# Altium schematic sheet lv_comm_i2c.SchDoc(lv_comm_i2c)
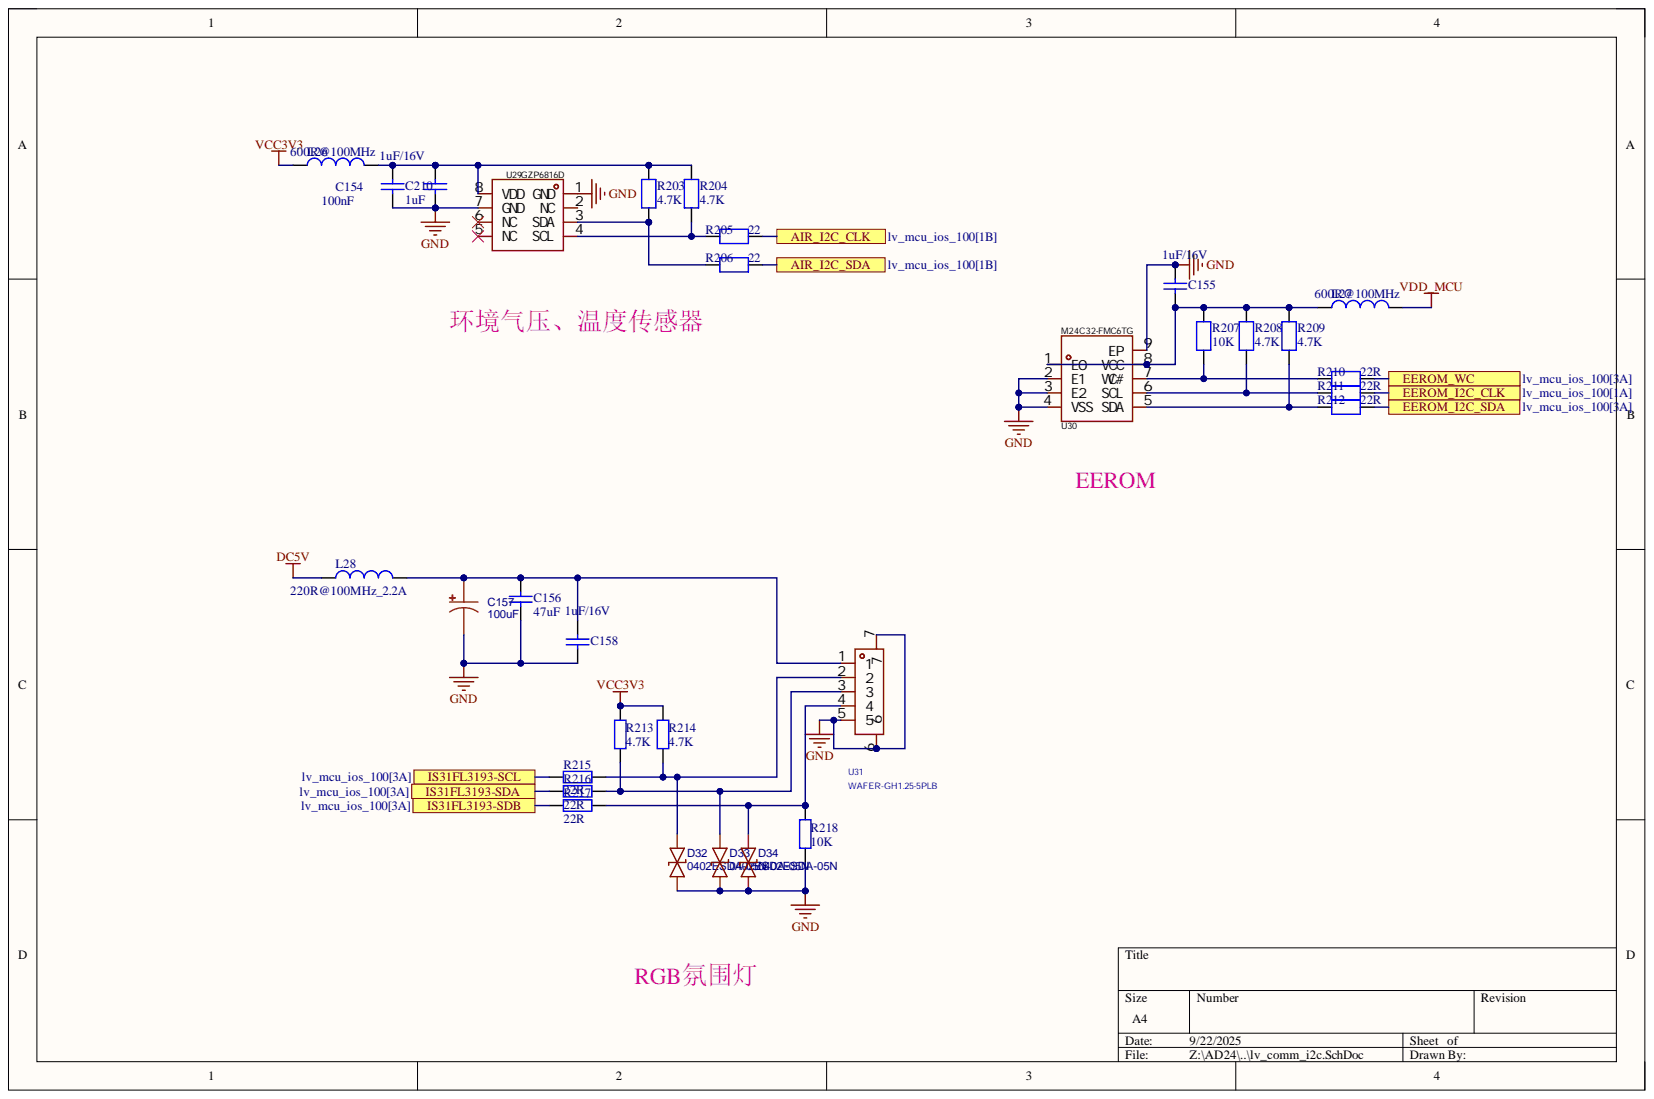
[diagram p0: lv_comm_i2c.SchDoc]

## Parts
C154  100nF  [C0402]
C155  1uF/16V  [C0603]
C156  47uF  [C1210]
C157  100uF  [CAP-SMD_BD6.3-L6.6-W6.6-LS7.6-FD]
C158  1uF/16V  [C0603]
C210  1uF/16V  value 1uF  [C0603]
D32  0402ESDA-05N  [D0402-BI]
D33  0402ESDA-05N  [D0402-BI]
D34  0402ESDA-05N  [D0402-BI]
L26  600R@100MHz  [L0603]
L27  600R@100MHz  [L0603]
L28  220R@100MHz_2.2A  [L0603]
R203  4.7K  [R0402]
R204  4.7K  [R0402]
R205  22  [R0402]
R206  22  [R0402]
R207  10K  [R0402]
R208  4.7K  value 10K  [R0402]
R209  4.7K  [R0402]
R210  22R  [R0402]
R211  22R  [R0402]
R212  22R  [R0402]
R213  4.7K  [R0402]
R214  4.7K  [R0402]
R215  22R  [R0402]
R216  22R  [R0402]
R217  22R  [R0402]
R218  10K  [R0402]
U29  GZP6816D  [SENSOR-SMD_XGZP6816D]
U30  M24C32-FMC6TG  [UFDFPN-8_L2.0-W3.0-P0.50-BL-EP]
U31  WAFER-GH1.25-5PLB  [CONN-SMD_5P-P1.25_XUNPU_WAFER-GH1.25-5PLB]

## Ports
AIR_I2C_CLK, AIR_I2C_SDA, EEROM_I2C_CLK, EEROM_I2C_SDA, EEROM_WC, IS31FL3193-SCL, IS31FL3193-SDA, IS31FL3193-SDB

## Nets
DC5V: L28.2
GND: C154.1 C155.1 C156.1 C157.2 C158.2 C210.2 D32.1 D33.1 D34.1 R218.1 U29.1(GND) U29.7(GND) U30.2(E1) U30.3(E2) U30.4(VSS) U30.9(EP) U31.5 U31.6 U31.7
VCC3V3: L26.1 R213.1 R214.1
VDD_MCU: L27.2
AIR_I2C_CLK: R205.2 ; port AIR_I2C_CLK
AIR_I2C_SDA: R206.2 ; port AIR_I2C_SDA
EEROM_I2C_CLK: R211.1 ; port EEROM_I2C_CLK
EEROM_I2C_SDA: R212.1 ; port EEROM_I2C_SDA
EEROM_WC: R210.1 ; port EEROM_WC
IS31FL3193-SCL: R215.2 ; port IS31FL3193-SCL
IS31FL3193-SDA: R216.2 ; port IS31FL3193-SDA
IS31FL3193-SDB: R217.2 ; port IS31FL3193-SDB
NetC154_2: C154.2 C210.1 L26.2 R203.1 R204.1 U29.8(VDD)
NetC155_2: C155.2 L27.1 R207.2 R208.2 R209.2 U30.1(E0) U30.8(VCC)
NetC156_2: C156.2 C157.1 C158.1 L28.1 U31.1
NetD32_2: D32.2 R214.2 R215.1 U31.2
NetD33_2: D33.2 R213.2 R216.1 U31.3
NetD34_2: D34.2 R217.1 R218.2 U31.4
NetR203_2: R203.2 R206.1 U29.3(SDA)
NetR204_2: R204.2 R205.1 U29.4(SCL)
NetR207_1: R207.1 R210.2 U30.7(WC#)
NetR208_1: R208.1 R211.2 U30.6(SCL)
NetR209_1: R209.1 R212.2 U30.5(SDA)

Not connected: U29 2(NC) 5(NC) 6(NC)

## Library data of the parts
C154 = Capacitor: 50V, 5%, X7R; Manufacturer: muRata; Manufacturer_Part_Number: GRM155R71H104KE14D; Component Kind: Standard; ComponentLink1Description: Datasheet; ComponentLink1URL: http://tunmcdsvn.tun.st.com/Component_Datasheet_Library/Trunk/Capacitors/Yageo_Capacitor_NP0_16V-to-50V; Library Name: HiPin_Library_AD.IntLib
C155 C158 = MCD-00010: 16V, X7R, 10%; Manufacturer: muRata; Manufacturer_Part_Number: GRM188R71C105KE15D; Database Table Name: MCD_PARTS$; Component Kind: Standard; Library Name: MCD_PARTS_database.DbLib
  C158: ComponentLink1Description: Yageo_Capacitor_X5R-series.pdf; ComponentLink1URL: http://tunmcdsvn.tun.st.com/Component_Datasheet_Library/Trunk/Capacitors/Yageo_Multilayer_capacitor_X5R_series_100pF_to_100uF
C156 = Capacitor_3: 16V, X5R, 20%; Internal_Part_Number: MCD-00008; Manufacturer: muRata; Manufacturer_Part_Number: GRM32ER61C476KE15L; Component Kind: Standard; Library Name: HiPin_Library_AD.IntLib; Value: 47uF
C157 = 100uF: 6.3mm*7.7mm; BOM_Manufacturer: Nichicon; BOM_Manufacturer Part: UWT1V101MCL1GS; Contributor: LCSC; nameAlias: Capacitance; BOM_JLCPCB Part Class: Extended Part; BOM_Supplier: LCSC; BOM_Supplier Part: C125975; Component Kind: Standard; Library Name: HiPin_Library_AD.IntLib; spicePre: C; spiceSymbolName: UWT1V101MCL1GS
C210 = MCD-00010: 16V, X7R, 10%; Manufacturer: muRata; Manufacturer_Part_Number: GRM188R71C105KE15D; Part_Type: Capacitor; Database Table Name: MCD_PARTS$; Initial_Board_Reference: MB1274; Provided_By: Subcon; Component Kind: Standard; ECCN_EU: n/a; ECCN_US: n/a; Internal_Part_Number: MCD-00010; Library Name: MCD_PARTS_database.DbLib; Package: C0603; Status: Released
D32-D34 = 0402ESDA-05N: BOM_Manufacturer: Bourne Semicon (Shenzhen); Contributor: LCSC; BOM_JLCPCB Part Class: Extended Part; BOM_Manufacturer Part: 0402ESDA-05N; BOM_Supplier: LCSC; BOM_Supplier Part: C316049; Component Kind: Standard; Library Name: HiPin_Library_AD.IntLib; spicePre: D; spiceSymbolName: 0402ESDA-05N
L26 L27 = MCD-00047: +/-25%, 350mA, 500mohm DC; Manufacturer: TAI-TECH; Manufacturer_Part_Number: FCM1608KF-601T03; Database Table Name: MCD_PARTS$; Component Kind: Standard; ComponentLink1Description: Datasheet; ComponentLink1URL: http://tunmcdsvn.tun.st.com/Component_Datasheet_Library/Trunk/Inductors/TaiTech_Bead_FCM1608_series; Library Name: MCD_PARTS_database.DbLib
L28 = MCD-00047: SMD Chip ferrite bead, 220R@100MHz +/-25%,2.2A, 50mohm; Manufacturer: Sunlord; Manufacturer_Part_Number: UPZ1608U221-2R2TF; Database Table Name: MCD_PARTS$; Component Kind: Standard; ComponentLink1Description: Datasheet; ComponentLink1URL: http://tunmcdsvn.tun.st.com/Component_Datasheet_Library/Trunk/Inductors/TaiTech_Bead_FCM1608_series; Internal_Part_Number: MCD-00047; Library Name: MCD_PARTS_database.DbLib
R203-R206 R209-R212 = RES: 1%; Value: 10K; Component Kind: Standard; Library Name: HiPin_Library_AD.IntLib
R207 R208 = RES: 1%; Component Kind: Standard; Library Name: HiPin_Library_AD.IntLib
  R207: Value: 10K
R213-R218 = 0603 49.9KΩ (4992) 1%: 贴片电阻 1% 1/16W 100ppm; Manufacturer: YAGEO; ManufacturerNumber: RC0402FR-0710KL; Component Kind: Standard; Library Name: RES.SchLib; SuppliersPartNumber: C60490
U29 = GZP6816D: Manufacturer: Sencoch(芯感智); Add into BOM: yes; Pressure range: 30Kpa~110Kpa; Standby Current: 50nA; The interface type: I2C; The Power Supply Voltage: 3.3V; Component Kind: Standard; Convert to PCB: yes; Datasheet: https://atta.szlcsc.com/upload/public/pdf/source/20220921/E22C263645ADA222538EFE98701C343D.pdf; Device: GZP6816D; JLCPCB Part Class: Extended Part; LCSC Part Name: 压力传感器GZP6816D; Library Name: HiPin_Library_AD.IntLib; Manufacturer Part: GZP6816D; Operating Temperature: -30℃~+85℃; PCB3D: SENSOR-SMD_XGZP6816D; Supplier: LCSC; Supplier Footprint: SMD-8P,2x2.5mm; Supplier Part: C3038127; Symbol: GZP6816D
U30 = M24C32-FMC6TG: Manufacturer: ST(意法半导体); Add into BOM: yes; Interface Type: I2C; Memory Size: 32Kbit; Supply Voltage: 1.7V~5.5V; Component Kind: Standard; Convert to PCB: yes; Datasheet: https://atta.szlcsc.com/upload/public/pdf/source/20181022/C283444_BCD956C595255ABAD7DDB42E45293D41.pdf; Device: M24C32-FMC6TG; JLCPCB Part Class: Extended Part; LCSC Part Name: M24C32 FMC6TG; Library Name: HiPin_Library_AD.IntLib; Manufacturer Part: M24C32-FMC6TG; Operating Temperature: -40℃~+85℃; PCB3D: UFDFPN-8_L2.0-W3.0-H0.6-P0.50-BL-EP; Supplier: LCSC; Supplier Footprint: UFDFPN-8(2x3); Supplier Part: C2060985; Symbol: M24C32-FMC6TG
U31 = WAFER-GH1.25-5PLB: Manufacturer: XUNPU(讯普); Add into BOM: yes; Current Rating: 1A; Number of PINs Per Row: 5; Pins Structure: 1x5P; Pitch: 1.25mm; Plastic Material: LCP; Reference Series: GH; Supplementary Features: 辅助焊脚; Voltage Rating: 125V; X-Length of Bottom Edge on Board (Spacing Line): 9.5mm; Y-Width of Bottom Edge on Board: 4.95mm; Z-Height of the Board: 4.2mm; Color: 米色; Component Kind: Standard; Contact Material: 黄铜; Contact Plating: -; Convert to PCB: yes; Datasheet: https://atta.szlcsc.com/upload/public/pdf/source/20220527/44F824AAC8C2B617205E15955FF9C23D.pdf; Device: WAFER-GH1.25-5PLB; Flame Retardant Rating: -; JLCPCB Part Class: Extended Part; LCSC Part Name: 1x5P 间距:1.25mm 立贴 系列:GH; Library Name: HiPin_Library_AD.IntLib; Manufacturer Part: WAFER-GH1.25-5PLB; Mounting Type: 立贴; Number of Pins: 5P; Number of Rows: 1; Operating Temperature: -25℃~+85℃; PCB3D: CONN-SMD_5P-P1.25_XUNPU_WAFER-GH1.25-5PLB; Row Spacing: -; Supplier: LCSC; Supplier Footprint: SMD,P=1.25mm; Supplier Part: C3029371; Symbol: WAFER-GH1.25-5PLB
